# Altium schematic sheet STM32_MCU.SchDoc
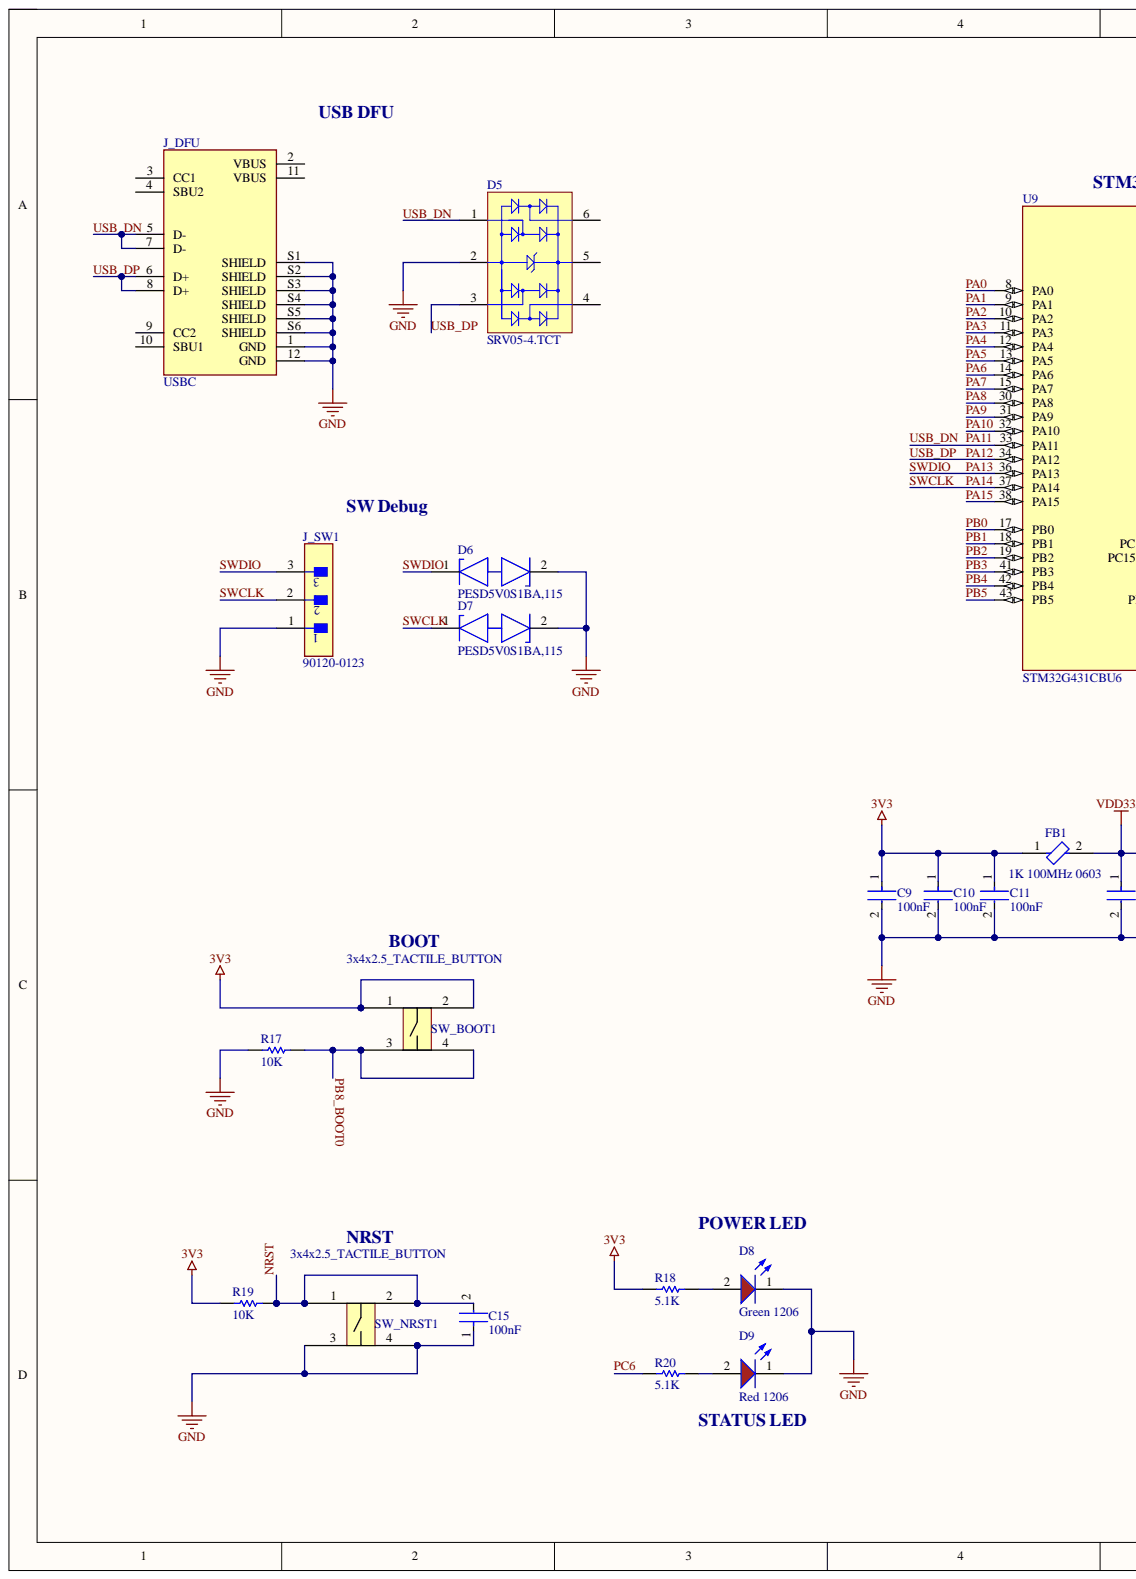
[diagram p0: STM32_MCU.SchDoc, left]
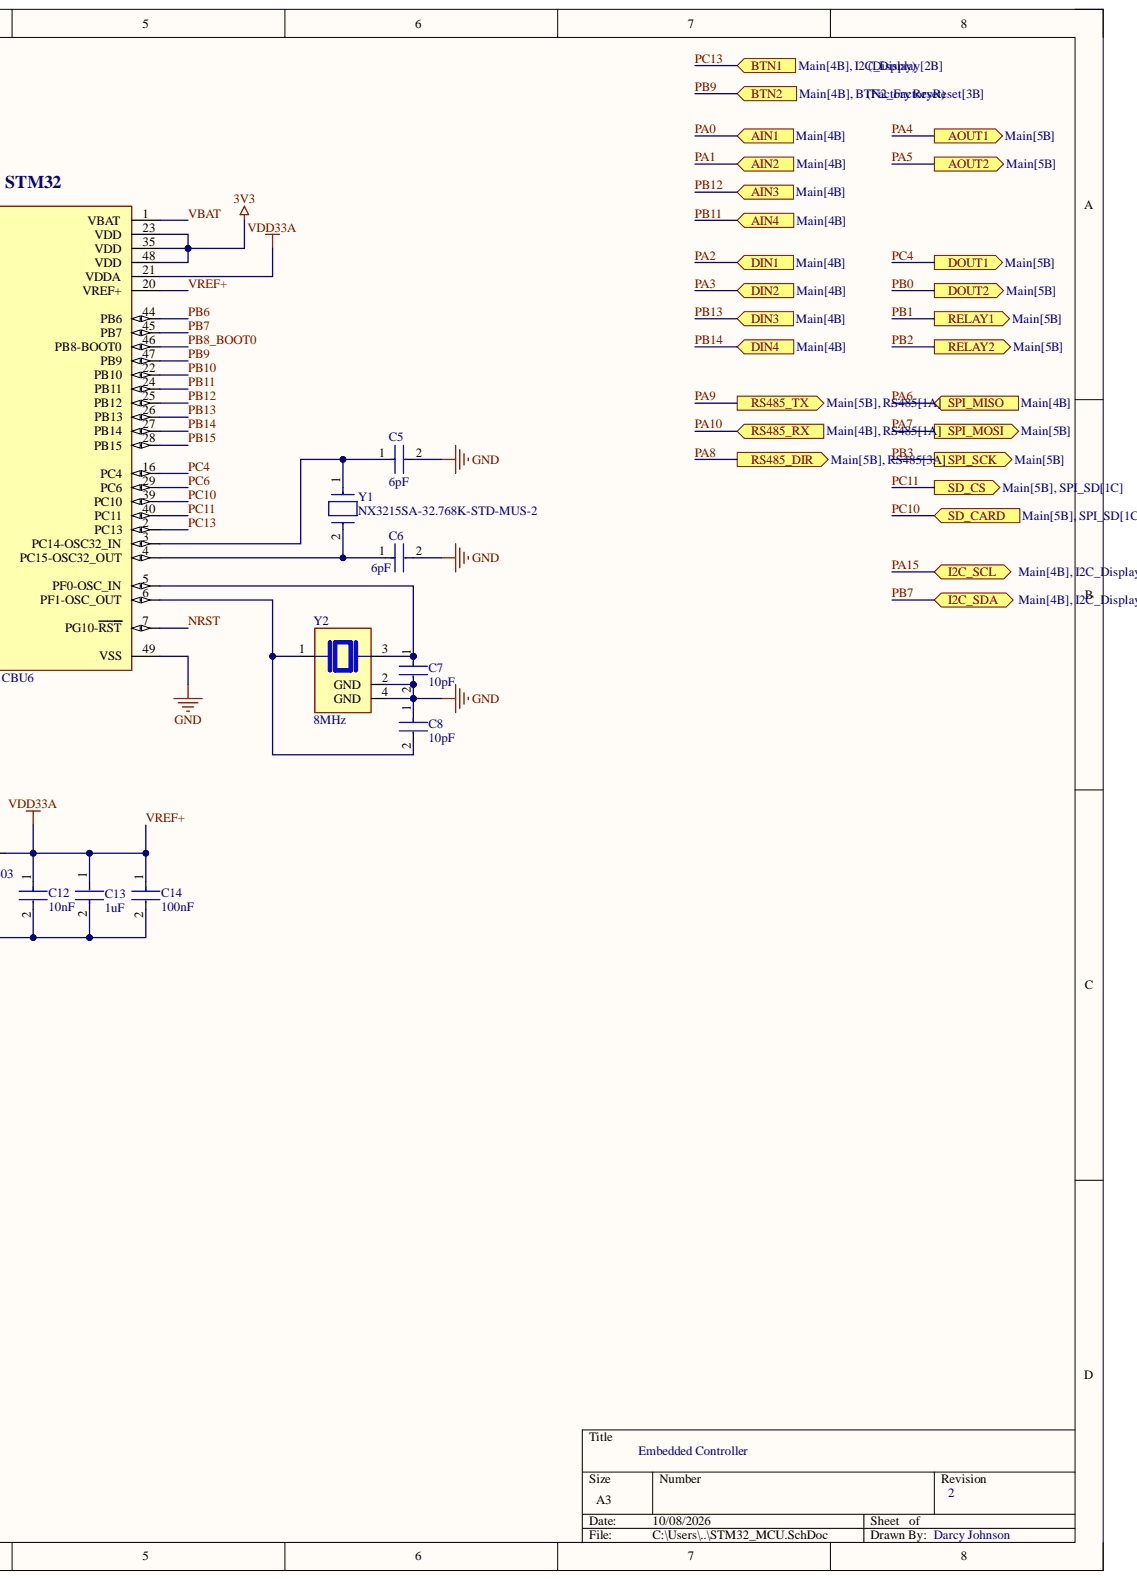
[diagram p1: STM32_MCU.SchDoc, right]

## Parts
C5  6pF  [FP-CGA3E-0_3-0_1-MFG]
C6  6pF  [FP-CGA3E-0_3-0_1-MFG]
C7  10pF  [FP-C0603C-CF-MFG]
C8  10pF  [FP-C0603C-CF-MFG]
C9  100nF  [FP-CGA3E-0_3-0_1-IPC_A]
C10  100nF  [FP-CGA3E-0_3-0_1-IPC_A]
C11  100nF  [FP-CGA3E-0_3-0_1-IPC_A]
C12  10nF  [FP-CC0603-DA-MFG]
C13  1uF  [FP-C1608-080-0_1-IPC_B]
C14  100nF  [FP-CGA3E-0_3-0_1-IPC_A]
C15  100nF  [FP-CGA3E-0_3-0_1-IPC_A]
D5  SRV05-4.TCT  [SMTC-SOT23-6_V]
D6  PESD5V0S1BA,115  [FP-SOD323-CA-MFG]
D7  PESD5V0S1BA,115  [FP-SOD323-CA-MFG]
D8  Green 1206  Case Code (Imperial): 1206  [WL-SMCW_1206_150120xx75000]
D9  Red 1206  Case Code (Imperial): 1206  Color: Red  [WL-SMCW_1206_150120xx75000]
FB1  1K 100MHz 0603  Case Code (Imperial): 0603  Frequency: 100MHz  [FP-BLM18-0_15-t0_8-MFG]
J_DFU  USBC  [JAE_DX07S016JA1R1500]
J_SW1  90120-0123  [FP-90120-0123-MFG]
R17  10K  [RESC1609X55X30LL15T15]
R18  5.1K  [RESC1608X55X30LL15T15]
R19  10K  [RESC1609X55X30LL15T15]
R20  5.1K  [RESC1608X55X30LL15T15]
SW_BOOT1  3x4x2.5_TACTILE_BUTTON  [3x4x2.5_TACTILE_BUTTON]
SW_NRST1  3x4x2.5_TACTILE_BUTTON  [3x4x2.5_TACTILE_BUTTON]
U9  STM32G431CBU6  [QFN50P700X700X60-49N]
Y1  NX3215SA-32.768K-STD-MUS-2  [FP-NX3215SA-MFG]
Y2  8MHz  [XTAL_C3E-8.000-10-1020-M]

## Ports
AIN1, AIN2, AIN3, AIN4, AOUT1, AOUT2, BTN1, BTN2, DIN1, DIN2, DIN3, DIN4, DOUT1, DOUT2, I2C_SCL, I2C_SDA, RELAY1, RELAY2, RS485_DIR, RS485_RX, RS485_TX, SD_CARD, SD_CS, SPI_MISO, SPI_MOSI, SPI_SCK

## Nets
3V3: C9.1 C10.1 C11.1 FB1.1 R18.1 R19.1 SW_BOOT1.1 SW_BOOT1.2 U9.23(VDD) U9.35(VDD) U9.48(VDD)
GND: C5.2 C6.2 C7.2 C8.1 C9.2 C10.2 C11.2 C12.2 C13.2 C14.2 C15.1 D5.2 D6.2 D7.2 D8.1 D9.1 J_DFU.1(GND) J_DFU.12(GND) J_DFU.S1(SHIELD) J_DFU.S2(SHIELD) J_DFU.S3(SHIELD) J_DFU.S4(SHIELD) J_DFU.S5(SHIELD) J_DFU.S6(SHIELD) J_SW1.1 R17.1 SW_NRST1.3 SW_NRST1.4 U9.49(VSS) Y2.2(GND) Y2.4(GND)
NRST: C15.2 R19.2 SW_NRST1.1 SW_NRST1.2 U9.7(PG10-RST)
PA0: U9.8(PA0) ; port AIN1
PA1: U9.9(PA1) ; port AIN2
PA2: U9.10(PA2) ; port DIN1
PA3: U9.11(PA3) ; port DIN2
PA4: U9.12(PA4) ; port AOUT1
PA5: U9.13(PA5) ; port AOUT2
PA6: U9.14(PA6) ; port SPI_MISO
PA7: U9.15(PA7) ; port SPI_MOSI
PA8: U9.30(PA8) ; port RS485_DIR
PA9: U9.31(PA9) ; port RS485_TX
PA10: U9.32(PA10) ; port RS485_RX
PA11: D5.1 J_DFU.5(D-) J_DFU.7(D-) U9.33(PA11)
PA12: D5.3 J_DFU.6(D+) J_DFU.8(D+) U9.34(PA12)
PA13: D6.1 J_SW1.3 U9.36(PA13)
PA14: D7.1 J_SW1.2 U9.37(PA14)
PA15: U9.38(PA15) ; port I2C_SCL
PB0: U9.17(PB0) ; port DOUT2
PB1: U9.18(PB1) ; port RELAY1
PB2: U9.19(PB2) ; port RELAY2
PB3: U9.41(PB3) ; port SPI_SCK
PB4: U9.42(PB4)
PB5: U9.43(PB5)
PB6: U9.44(PB6)
PB7: U9.45(PB7) ; port I2C_SDA
PB8_BOOT0: R17.2 SW_BOOT1.3 SW_BOOT1.4 U9.46(PB8-BOOT0)
PB9: U9.47(PB9) ; port BTN2
PB10: U9.22(PB10)
PB11: U9.24(PB11) ; port AIN4
PB12: U9.25(PB12) ; port AIN3
PB13: U9.26(PB13) ; port DIN3
PB14: U9.27(PB14) ; port DIN4
PB15: U9.28(PB15)
PC4: U9.16(PC4) ; port DOUT1
PC6: R20.1 U9.29(PC6)
PC10: U9.39(PC10) ; port SD_CARD
PC11: U9.40(PC11) ; port SD_CS
PC13: U9.2(PC13) ; port BTN1
VBAT: U9.1(VBAT)
VREF+: C12.1 C13.1 C14.1 FB1.2 U9.20(VREF+) U9.21(VDDA)
NetC5_1: C5.1 U9.3(PC14-OSC32_IN) Y1.1
NetC6_1: C6.1 U9.4(PC15-OSC32_OUT) Y1.2
NetC7_1: C7.1 U9.5(PF0-OSC_IN) Y2.3
NetC8_2: C8.2 U9.6(PF1-OSC_OUT) Y2.1
NetD5_4: D5.4
NetD5_5: D5.5
NetD5_6: D5.6
NetD8_2: D8.2 R18.2
NetD9_2: D9.2 R20.2
NetJ_DFU_2: J_DFU.2(VBUS)
NetJ_DFU_3: J_DFU.3(CC1)
NetJ_DFU_4: J_DFU.4(SBU2)
NetJ_DFU_9: J_DFU.9(CC2)
NetJ_DFU_10: J_DFU.10(SBU1)
NetJ_DFU_11: J_DFU.11(VBUS)

## Library data of the parts
C5 C6 = CMP-08246-001317-1: Cap Ceramic 6pF 50V C0G 0.5pF Pad SMD 0603 +125°C Automotive T/R; Capacitance: 6pF; Case Code (Imperial): 0603; Case Code (Metric): 1608; Case/Package: 0603; Component Kind: Standard; Composition: Ceramic; Depth: 0.8mm; Dielectric: C0G; Height: 0.8mm; Length: 1.6mm; Library Name: Altium Content Vault; Max Operating Temperature: 125°C; Min Operating Temperature: -55°C; Mount: Surface Mount; Package Quantity: 1; Packaging: Tape and Reel; Ratings: AEC-Q200; REACH SVHC: No SVHC; Termination: SMD/SMT; Thickness: 0.035inch; Voltage Rating: 50V; Voltage Rating (DC): 50V; Weight: 7.1E-05oz; Width: 0.031inch
C7 C8 = CMP-2006-03343-2: CAP CER 10PF 50V NP0 0603; Manufacturer: KEMET; Manufacturer Part Number: C0603C100C5GACTU; Automotive Grade: Grade 1; Material: Ceramic; Temperature Characteristic: C0G; Temperature Coefficient: 30ppm/°C; Tolerance: 0.25 pF; Automotive: Yes; Capacitance: 10 pF; Category: Cap; Component Kind: Standard; ComponentLink2Description: Datasheet; ComponentLink2URL: https://api.kemet.com/component-edge/download/datasheet/C0603C100J5GACAUTO.pdf; Device Class L1: Passive Components; Device Class L2: Capacitors; Device Class L3: Ceramic Capacitors; Digikey Description: CAP CER 10PF 50V NP0 0603; Height: 0.87mm; Lead Free: Yes; Library Name: Altium Content Vault; Mouser Description: Multilayer Ceramic Capacitors MLCC - SMD/SMT 50volts 10pF C0G 0.25%; Octopart: https://octopart.com/c0603c100c5gactu-kemet-9138556; Package: C0603C-CF; RoHS: TRUE; Temperature: -55°C to +125°C; Temperature Range High: +125°C; Temperature Range Low: -55°C; Value: 10 pF; Voltage Rating: 50 V
C9-C11 C14 C15 = CMP-2000-07546-2: Cap Ceramic 100nF 50V X7R 10% Pad SMD 0603 +125°C Automotive T/R; Capacitance: 100nF; Case Code (Imperial): 0603; Case Code (Metric): 1608; Case/Package: 0603; Component Kind: Standard; Composition: Ceramic; Depth: 0.8mm; Dielectric: X7R; Height: 0.8mm; Lead Free: Lead Free; Length: 1.6mm; Library Name: Altium Content Vault; Max Operating Temperature: 125°C; Min Operating Temperature: -55°C; Mount: Surface Mount; Package Quantity: 1; Packaging: Tape and Reel; Radiation Hardening: No; Ratings: AEC-Q200; REACH SVHC: No SVHC; Termination: SMD/SMT; Thickness: 0.035inch; Tolerance: 10%; Voltage: 50V; Voltage Rating: 50V; Voltage Rating (DC): 50V; Weight: 7.1E-05oz; Width: 0.8mm
C12 = CMP-2100-03600-2: CAP CER 10000PF 50V X7R 0603; Manufacturer: YAGEO; Manufacturer Part Number: CC0603KRX7R9BB103; Material: Ceramic; Temperature Coefficient: 15%; Tolerance: 0.1; Automotive: No; Capacitance: 10 nF; Category: Cap; Component Kind: Standard; ComponentLink2Description: Datasheet; ComponentLink2URL: http://www.yageo.com/documents/recent/UPY-GPHC_X7R_6.3V-to-50V_18.pdf; Device Class L1: Passive Components; Device Class L2: Capacitors; Device Class L3: Ceramic Capacitors; Digikey Description: CAP CER 10000PF 50V X7R 0603; Footprint Url: http://www.yageo.com/exep/pages/download/literatures/UPY-C_GEN_24.pdf; Height: 0.9mm; Lead Free: Yes; Library Name: Altium Content Vault; Mouser Description: Multilayer Ceramic Capacitor, CC Series, 0.01 - F, - 10%, X7R, 50 V, 0603 [1608 Metric]; Octopart: https://octopart.com/cc0603krx7r9bb103-yageo-192938; Package: CC0603-DA; RoHS: TRUE; Temperature: -55°C to +125°C; Temperature Characteristic: X7R; Temperature Range High: +125°C; Temperature Range Low: -55°C; Value: 10 nF; Voltage: 50 V; Voltage Rating: 50 V
C13 = CMP-2000-06025-2: Multilayer Ceramic Capacitors 1μF ±10% 50V X5R SMD 0603; Capacitance: 1uF; Case Code (Imperial): 0603; Case Code (Metric): 1608; Case/Package: 0603; Component Kind: Standard; Construction: Flat; Depth: 0.8mm; Dielectric: X5R; Dielectric Material: Ceramic; Height: 0.8mm; Lead Free: Lead Free; Length: 1.6mm; Library Name: Altium Content Vault; Max Operating Temperature: 85°C; Min Operating Temperature: -55°C; Mount: Surface Mount; Number of Pins: 2; Package Quantity: 1; Packaging: Tape and Reel; Radiation Hardening: No; REACH SVHC: No SVHC; Resistor Type: Ceramic; RoHS Compliant: Yes; Series: C; Termination: SMD/SMT; Thickness: 0.035inch; Tolerance: 10%; Voltage: 50V; Voltage Rating: 50V; Voltage Rating (DC): 50V; Weight: 7.1E-05oz; Width: 0.031inch
D5 = CMP-2000-05358-1: RailClamp(R) Low Capacitance TVS Diode Array, 5 pF, 17.5 VC, -55 to 125 degC, 6-Pin SOT23, RoHS, Tape and Reel; Manufacturer: Semtech; Capacitance Value: 5pF; Clamping Voltage: 17.5V; Clamping Voltage (Max): 12.5V; Configuration: Quad; Direction Type: Uni-Directional; Leakage Current: 5uA; Number of Elements: 1; Peak Pulse Current: 12A; Peak Pulse Power Dissipation: 300W; Product Depth (mm): 1.6mm; Product Height (mm): 1.15mm; Product Length (mm): 2.9mm; Rad Hardened: No; Reverse Breakdown Voltage: 6V; Reverse Stand-off Voltage: 5V; Suppressor Type: DiodeArrays; Test Current (It): 1mA; Component Kind: Standard; Datasheet URL: https://datasheet.ciiva.com/8029/srv05-4-8029973.pdf; Datasheet Version: Jan-08; Leakage Current (Max): 5uA; Library Name: Altium Content Vault; Manufacturer URL: http://www.semtech.com/; Mounting: SurfaceMount; Mounting Style: SurfaceMount; Operating Temp Range: -55Cto125C; Operating Temperature Classification: Military; Operating Temperature Max Deg. C: 125C; Operating Temperature Min Deg. C: -55C; Package / Case: SOT-23; Package Description: 6-Pin Small Outline Transistor, Body 2.9 x 1.6 mm, Pitch 0.95 mm; Package Reference: SOT23-6; Packaging: TapeandReel; Pin Count: 6; Polarity: Uni-Directional; Working Voltage: 5V; Working Voltage (Max): 5V
D6 D7 = CMP-14432-000001-1: TVS DIODE 5V 14V SOD323; Component Kind: Standard; Library Name: Altium Content Vault
D8 D9 = CMP-1426-00017-3: Led, Red, 630 Nm, 1.9 V, 30 Ma, 60 Mcd Rohs Compliant: Yes; Dominant Wavelength: 630nm; Forward Current: 100mA; Forward Voltage: 1.9V; Lens Style: Clear, Rectangular; Luminous Intensity: 60mcd; Max Reverse Voltage (DC): 5V; Peak Wavelength: 645nm; Test Current: 20mA; Viewing Angle: 140°; Case Code (Metric): 3216; Case/Package: 1206; Component Kind: Standard; Height: 680µm; Length: 3.2mm; Library Name: Altium Content Vault; Max Operating Temperature: 85°C; Min Operating Temperature: -40°C; Mount: Surface Mount; Packaging: Tape and Reel; REACH SVHC: Unknown; RoHS: Compliant; Wavelength: 630nm; Width: 1.6mm
  D8: Color: Red
FB1 = CMP-0686-00457-3: Chip Ferrite Bead, 0603, 1000Ω @ 100MHz, 0.5Ω, 25%, 450mA; Current Rating: 400mA; DC Resistance (DCR): 500mR; DC Resistance-Max: 700mR; Impedance: 1kR; Material: Steel; Number of Circuits: 1; Shielding: Unshielded; Case Code (Metric): 1608; Case/Package: 0603; Component Kind: Standard; Core Material: Ferrite; DC Current: 400mA; Depth: 0.8mm; Height: 0.8mm; Lead Free: Lead Free; Length: 1.6mm; Library Name: Altium Content Vault; Max Current Rating: 400mA; Max DC Current: 400mA; Max Operating Temperature: 125°C; Military Standard: Not; Min Operating Temperature: -55°C; Number of Lines: 1; Number of Pins: 2; Package Quantity: 1; Packaging: Tape and Reel; Radiation Hardening: No; REACH SVHC: No SVHC; Resistance: 500mR; Series: BLM18A; Termination: SMD/SMT; Test Frequency: 100MHz; Tolerance: 25%; Width: 0.8mm
J_DFU = DX07S016JA1R1500: USB-C (USB TYPE-C) USB 2.0 Receptacle Connector 24 (16+8 Dummy) Position Surface Mount, Right Angle; Through Hole; Availability: Unavailable; Component Kind: Standard; Library Name: USBC_Fixed.SchLib; MP: DX07S016JA1R1500; Package: None; Price: None
J_SW1 = CMP-04776-000237-1: CONN HEADER VERT 3POS 2.54MM; Contact Post Length: 2.9mm; Contact Resistance: 20mR; Current Rating: 3A; Gender: Male; Glow Wire Compliant: Non-compliant; Max Voltage Rating (DC): 350.35kV; Number of Circuits: 3; Voltage Rating: 350V; Color: Black; Component Kind: Standard; Contact Gender: Male; Contact Material: Brass; Contact Mating Length: 6.75mm; Contact Plating: Tin; Housing Color: Black; Housing Material: Polyester; Lead Free: Lead Free; Library Name: Altium Content Vault; Max Operating Temperature: 125°C; Min Operating Temperature: -55°C; Mount: Through Hole; Number of Contacts: 3; Number of Positions: 3; Number of Rows: 1; Orientation: Vertical; Packaging: Tray; Pitch: 2.54mm; Radiation Hardening: No; REACH SVHC: No SVHC; RoHS Compliant: Yes; Series: 90120; Termination: Solder; Voltage Rating (AC): 350V
R17 R19 = CMP-1012-00623-3: Chip Resistor, 10 KOhm, +/- 1%, 0.1 W, -55 to 155 degC, 0603 (1608 Metric), RoHS, Tape and Reel; Manufacturer: Panasonic; Case\/Package: 0603; Max Power Dissipation: 100mW; Resistance: 10KOhm; Temperature Coefficient: 100ppm\/degreesC; Voltage Rating: 75V; Case Code (Imperial): 0603; Case Code (Metric): 1608; Component Kind: Standard; Composition: ThickFilm; Contact Plating: Tin; Datasheet URL: https://industrial.panasonic.com/cdbs/www-data/pdf/RDA0000/AOA0000C304.pdf; Datasheet Version: 02/2017; Depth: 0.8mm; Height: 0.45mm; Lead Free: LeadFree; Length: 1.6mm; Library Name: Altium Content Vault; Manufacturer URL: http://www.panasonic.com/; Max Operating Temperature: 155degC; Military Standard: Not; Min Operating Temperature: -55degC; Mount: SurfaceMount; Mounting Technology: SurfaceMount; Number of Pins: 2; Number of Terminations: 2; Package Description: 2-Pin Surface Mount Device, Body 1.6 x 0.85 mm; Package Quantity: 1; Package Reference: 603; Packaging: TapeandReel; Power Rating: 100mW; Radiation Hardening: No; REACH SVHC: NoSVHC; RoHSCompliant: true; Termination: SMD/SMT; Tolerance: 1%; Voltage Rating (DC): 75V; Width: 0.031inch
R18 R20 = CMP-2000-00803-1: Manufacturer: Panasonic; Part Number: ERJ-3GEYJ512V; Component Kind: Standard; Library Name: Altium Content Vault
U9 = STM32G431CBU6: ARMÂ® CortexÂ®-M4F STM32G4 Microcontroller IC 32-Bit Single-Core 170MHz 128KB (128K x 8) FLASH 48-UFQFPN (7x7); MF: STMicroelectronics; Check_prices: https://www.snapeda.com/parts/STM32G431CBU6/STMicroelectronics/view-part/?ref=eda; Component Kind: Standard; Library Name: STM32G431CBU6.IntLib; MP: STM32G431CBU6; Package: UFQFPN-48 STMicroelectronics; SnapEDA_Link: https://www.snapeda.com/parts/STM32G431CBU6/STMicroelectronics/view-part/?ref=snap
Y1 = CMP-200220-000010-1: CRYSTAL 32.7680KHZ 12.5PF SMD; ESR (Equivalent Series Resistance): 70kR; Frequency: 32.768kHz; Frequency Tolerance: 20ppm; Case/Package: SMD/SMT; Component Kind: Standard; Height: 0.035inch; Length: 0.126inch; Library Name: Altium Content Vault; Load Capacitance: 12.5pF; Max Operating Temperature: 125°C; Min Operating Temperature: -40°C; Mount: Surface Mount; Number of Pins: 2; Package Quantity: 1000; Packaging: Tape and Reel; Width: 0.059inch
Y2 = C3E-8.000-10-1020-M: Availability: Unavailable; Component Kind: Standard; Library Name: C3E-8.000-10-1020-M.IntLib; MP: C3E-8.000-10-1020-M; Package: None; Price: None
SW_BOOT1 SW_NRST1 = 3x4x2.5_TACTILE_BUTTON: Component Kind: Standard; Library Name: 3x4x2.5_TACTILE_BUTTON.SchLib
